# Altium schematic sheet PIXRACER-SERIALS-V1-R15.SchDoc(PIXRACER-SERIALS-V1-R15)
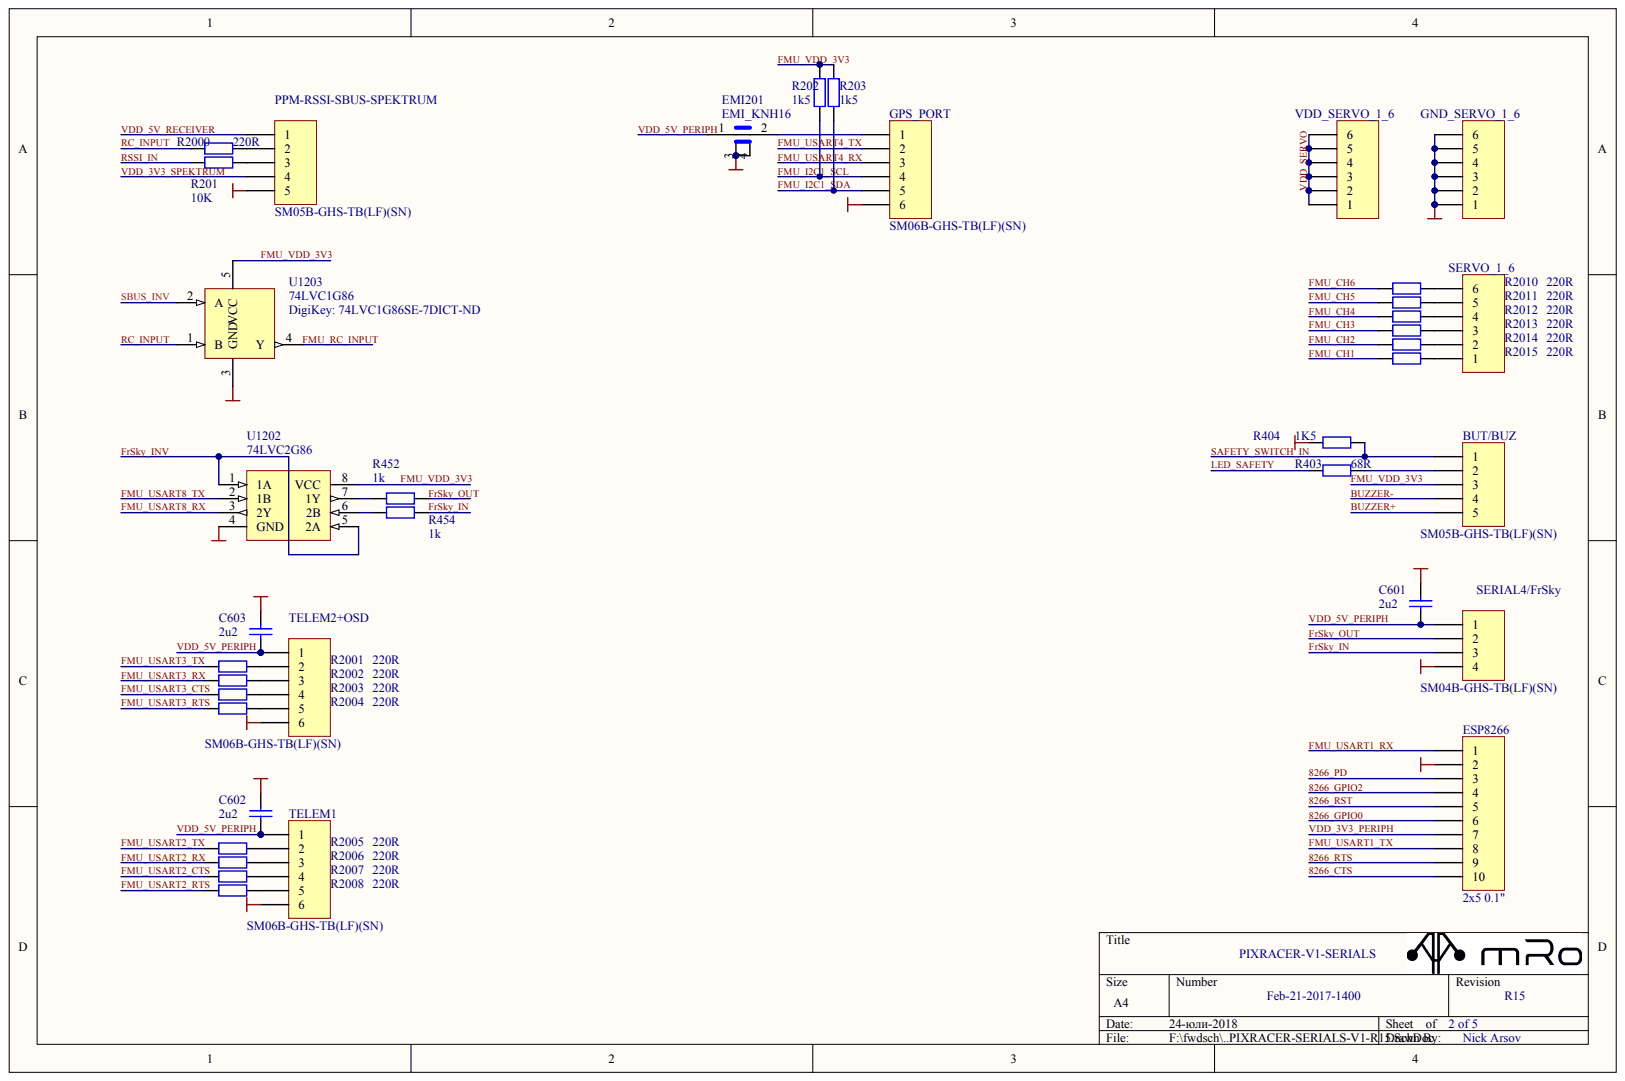
[diagram p0: PIXRACER-SERIALS-V1-R15.SchDoc]

## Parts
BUT/BUZ  SM05B-GHS-TB(LF)(SN)
C601  2u2
C602  2u2
C603  2u2
EMI201  EMI_KNH16
ESP8266  2x5 0.1"
GND_SERVO_1_6  Header 6  (value not drawn)
GPS_PORT  SM06B-GHS-TB(LF)(SN)
PPM-RSSI-SBUS-SPEKTRUM  SM05B-GHS-TB(LF)(SN)
R201  10K
R202  1k5
R203  1k5
R403  68R
R404  1K5
R452  1k
R454  1k
R2000  220R
R2001  220R
R2002  220R
R2003  220R
R2004  220R
R2005  220R
R2006  220R
R2007  220R
R2008  220R
R2010  220R
R2011  220R
R2012  220R
R2013  220R
R2014  220R
R2015  220R
SERIAL4/FrSky  SM04B-GHS-TB(LF)(SN)
SERVO_1_6  Header 6  (value not drawn)
TELEM1  SM06B-GHS-TB(LF)(SN)
TELEM2+OSD  SM06B-GHS-TB(LF)(SN)
U1202  74LVC2G86
U1203  74LVC1G86
VDD_SERVO_1_6  Header 6  (value not drawn)

## Nets
GND: C601.2 C602.2 C603.2 EMI201.3 EMI201.4 ESP8266.2 GND_SERVO_1_6.1 GND_SERVO_1_6.2 GND_SERVO_1_6.3 GND_SERVO_1_6.4 GND_SERVO_1_6.5 GND_SERVO_1_6.6 GPS_PORT.6 PPM-RSSI-SBUS-SPEKTRUM.5 R404.2 SERIAL4/FrSky.4 TELEM1.6 TELEM2+OSD.6 U1202.4(GND) U1203.3(GND)
8266_CTS: ESP8266.10
8266_GPIO0: ESP8266.6
8266_GPIO2: ESP8266.4
8266_PD: ESP8266.3
8266_RST: ESP8266.5
8266_RTS: ESP8266.9
BUZZER+: BUT/BUZ.5
BUZZER-: BUT/BUZ.4
FMU_CH1: R2015.2
FMU_CH2: R2014.2
FMU_CH3: R2013.2
FMU_CH4: R2012.2
FMU_CH5: R2011.2
FMU_CH6: R2010.2
FMU_I2C1_SCL: GPS_PORT.4 R202.2
FMU_I2C1_SDA: GPS_PORT.5 R203.2
FMU_RC_INPUT: U1203.4(Y)
FMU_USART1_RX: ESP8266.1
FMU_USART1_TX: ESP8266.8
FMU_USART2_CTS: R2007.2
FMU_USART2_RTS: R2008.2
FMU_USART2_RX: R2006.2
FMU_USART2_TX: R2005.2
FMU_USART3_CTS: R2003.2
FMU_USART3_RTS: R2004.2
FMU_USART3_RX: R2002.2
FMU_USART3_TX: R2001.2
FMU_USART4_RX: GPS_PORT.3
FMU_USART4_TX: GPS_PORT.2
FMU_USART8_RX: U1202.3(2Y)
FMU_USART8_TX: U1202.2(1B)
FMU_VDD_3V3: BUT/BUZ.3 R202.1 R203.1 U1202.8(VCC) U1203.5(VCC)
FRSKY_IN: R454.1 SERIAL4/FrSky.3
FRSKY_INV: U1202.1(1A) U1202.5(2A)
FRSKY_OUT: R452.1 SERIAL4/FrSky.2
LED_SAFETY: R403.2
RC_INPUT: R2000.2 U1203.1(B)
RSSI_IN: R201.2
SAFETY_SWITCH_IN: BUT/BUZ.1 R404.1
SBUS_INV: U1203.2(A)
VDD_3V3_PERIPH: ESP8266.7
VDD_3V3_SPEKTRUM: PPM-RSSI-SBUS-SPEKTRUM.4
VDD_5V_PERIPH: C601.1 C602.1 C603.1 EMI201.1 SERIAL4/FrSky.1 TELEM1.1 TELEM2+OSD.1
VDD_5V_RECEIVER: PPM-RSSI-SBUS-SPEKTRUM.1
VDD_SERVO: VDD_SERVO_1_6.1 VDD_SERVO_1_6.2 VDD_SERVO_1_6.3 VDD_SERVO_1_6.4 VDD_SERVO_1_6.5 VDD_SERVO_1_6.6
NetBUT/BUZ_2: BUT/BUZ.2 R403.1
NetEMI201_2: EMI201.2 GPS_PORT.1
NetPPM-RSSI-SBUS-SPEKTRUM_2: PPM-RSSI-SBUS-SPEKTRUM.2 R2000.1
NetPPM-RSSI-SBUS-SPEKTRUM_3: PPM-RSSI-SBUS-SPEKTRUM.3 R201.1
NetR452_2: R452.2 U1202.7(1Y)
NetR454_2: R454.2 U1202.6(2B)
NetR2001_1: R2001.1 TELEM2+OSD.2
NetR2002_1: R2002.1 TELEM2+OSD.3
NetR2003_1: R2003.1 TELEM2+OSD.4
NetR2004_1: R2004.1 TELEM2+OSD.5
NetR2005_1: R2005.1 TELEM1.2
NetR2006_1: R2006.1 TELEM1.3
NetR2007_1: R2007.1 TELEM1.4
NetR2008_1: R2008.1 TELEM1.5
NetR2010_1: R2010.1 SERVO_1_6.6
NetR2011_1: R2011.1 SERVO_1_6.5
NetR2012_1: R2012.1 SERVO_1_6.4
NetR2013_1: R2013.1 SERVO_1_6.3
NetR2014_1: R2014.1 SERVO_1_6.2
NetR2015_1: R2015.1 SERVO_1_6.1

## Library data of the parts
C601-C603 = (no symbol name): Value: 100pF; Published: 8-Jun-2000; LatestRevisionDate: 17-Jul-2002; LatestRevisionNote: Re-released for DXP Platform.; PackageReference: RAD-0.3; Publisher: Altium Limited
R201-R203 R403 R404 R2000-R2008 R2010-R2015 = (no symbol name): Value: 1K; Published: 8-Jun-2000; LatestRevisionDate: 17-Jul-2002; LatestRevisionNote: Re-released for DXP Platform.; PackageReference: AXIAL-0.4; Publisher: Altium Limited
  R202 R203: PackageDescription: Axial Device, Thru-Hole; 2 Leads; 0.4 in Pin Spacing
BUT/BUZ EMI201 ESP8266 GND_SERVO_1_6 GPS_PORT PPM-RSSI-SBUS-SPEKTRUM R452 R454 SERIAL4/FrSky SERVO_1_6 TELEM1 TELEM2+OSD U1202 U1203 VDD_SERVO_1_6 = (no symbol name)
  BUT/BUZ ESP8266 GND_SERVO_1_6 GPS_PORT PPM-RSSI-SBUS-SPEKTRUM SERIAL4/FrSky SERVO_1_6 TELEM1 TELEM2+OSD VDD_SERVO_1_6: LatestRevisionDate: 17-Jul-2002; LatestRevisionNote: Re-released for DXP Platform.
  R452 R454: Published: 8-Jun-2000; LatestRevisionDate: 17-Jul-2002; LatestRevisionNote: Re-released for DXP Platform.; PackageReference: AXIAL-0.4; PackageDescription: Axial Device, Thru-Hole; 2 Leads; 0.4 in Pin Spacing; Value: 1K
  U1203: DigiKey: 74LVC1G86SE-7DICT-ND
  BUT/BUZ ESP8266 GND_SERVO_1_6 GPS_PORT PPM-RSSI-SBUS-SPEKTRUM R452 R454 SERIAL4/FrSky SERVO_1_6 TELEM1 TELEM2+OSD VDD_SERVO_1_6: Publisher: Altium Limited
